# Altium schematic sheet CalNv1.1.SchDoc
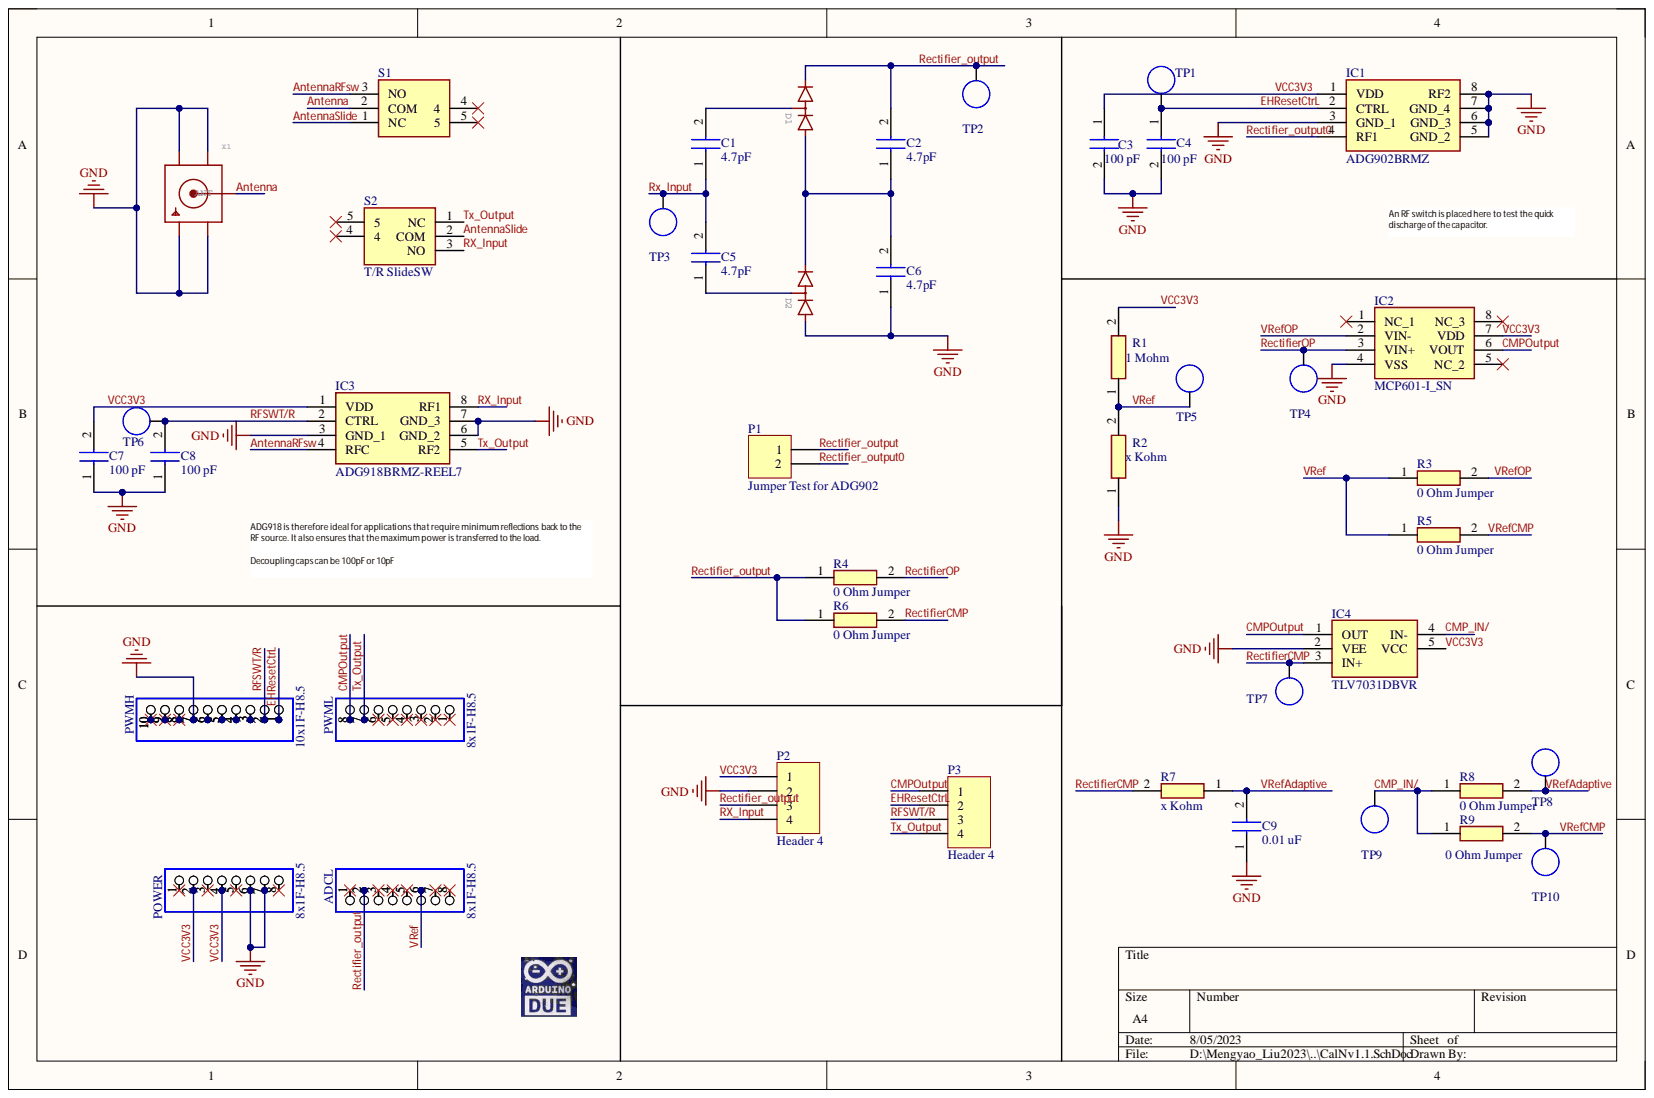
[diagram p0: CalNv1.1.SchDoc]

## Parts
ADCL  8x1F-H8.5  [1X08]
C1  4.7pF  [CAPC2012X95N]
C2  4.7pF  [CAPC2012X95N]
C3  100 pF  [CAPC2012X145N]
C4  100 pF  [CAPC2012X145N]
C5  4.7pF  [CAPC2012X95N]
C6  4.7pF  [CAPC2012X95N]
C7  100 pF  [CAPC2012X145N]
C8  100 pF  [CAPC2012X145N]
C9  0.01 uF  [CAPC2012X145N]
D1  RB706F-40FHT106  [SOT23]  (value not drawn)
D2  RB706F-40FHT106  [SOT23]  (value not drawn)
IC1  ADG902BRMZ  [SOP65P490X110-8N]
IC2  MCP601-I_SN  [SOIC127P600X175-8N]
IC3  ADG918BRMZ-REEL7  [SOP65P490X110-8N]
IC4  TLV7031DBVR  [SOT95P280X145-5N]
P1  Jumper Test for ADG902  [HDR1X2]
P2  Header 4  [HDR1X4]
P3  Header 4  [HDR1X4]
POWER  8x1F-H8.5  [1X08]
PWMH  10x1F-H8.5  [1X10]
PWML  8x1F-H8.5  [1X08]
R1  1 Mohm  [RESC2012X50N]
R2  x Kohm  [RESC2012X50N]
R3  0 Ohm Jumper  [RCC0805_e3]
R4  0 Ohm Jumper  [RCC0805_e3]
R5  0 Ohm Jumper  [RCC0805_e3]
R6  0 Ohm Jumper  [RCC0805_e3]
R7  x Kohm  [RESC2012X50N]
R8  0 Ohm Jumper  [RCC0805_e3]
R9  0 Ohm Jumper  [RCC0805_e3]
S1  Slide  [500ASSP1M6QE]
S2  T/R SlideSW  [500ASSP1M6QE]
TP1  TP  [TestPoint]  (no BOM)
TP2  TP  [TestPoint]  (no BOM)
TP3  TP  [TestPoint]  (no BOM)
TP4  TP  [TestPoint]  (no BOM)
TP5  TP  [TestPoint]  (no BOM)
TP6  TP  [TestPoint]  (no BOM)
TP7  TP  [TestPoint]  (no BOM)
TP8  TP  [TestPoint]  (no BOM)
TP9  TP  [TestPoint]  (no BOM)
TP10  TP  [TestPoint]  (no BOM)
X1  SMACONNECTOR_EDGE  [SMA_EDGELAUNCH]  (value not drawn)

## Nets
GND: C3.2 C4.2 C6.1 C7.1 C8.1 C9.1 D2.1 IC1.3(GND_1) IC1.5(GND_2) IC1.6(GND_3) IC1.7(GND_4) IC1.8(RF2) IC2.4(VSS) IC3.3(GND_1) IC3.6(GND_2) IC3.7(GND_3) IC4.2(VEE) P2.2 POWER.6 POWER.7 PWMH.7 R2.1 X1.GND@1 X1.GND@2 X1.GND@3 X1.GND@4
Antenna: S1.2(COM) X1.P(ANT)
AntennaRFsw: IC3.4(RFC) S1.3(NO)
AntennaSlide: S1.1(NC) S2.2(COM)
CMP_IN/: IC4.4(IN-) R8.1 R9.1 TP9.TP
CMPOutput: IC2.6(VOUT) IC4.1(OUT) P3.1 PWML.8
EHResetCtrL: C4.1 IC1.2(CTRL) P3.2 PWMH.1 TP1.TP
Rectifier_output: ADCL.2 C2.2 D1.2 P1.1 P2.3 R4.1 R6.1 TP2.TP
Rectifier_output0: IC1.4(RF1) P1.2
RectifierCMP: IC4.3(IN+) R6.2 R7.2 TP7.TP
RectifierOP: IC2.3(VIN+) R4.2 TP4.TP
RFSWT/R: C8.2 IC3.2(CTRL) P3.3 PWMH.2 TP6.TP
Rx_Input: C1.1 C5.2 IC3.8(RF1) P2.4 S2.3(NO) TP3.TP
Tx_Output: IC3.5(RF2) P3.4 PWML.7 S2.1(NC)
VCC3V3: C3.1 C7.2 IC1.1(VDD) IC2.7(VDD) IC3.1(VDD) IC4.5(VCC) P2.1 POWER.2 POWER.4 R1.2
VRef: ADCL.6 R1.1 R2.2 R3.1 R5.1 TP5.TP
VRefAdaptive: C9.2 R7.1 R8.2 TP8.TP
VRefCMP: R5.2 R9.2 TP10.TP
VRefOP: IC2.2(VIN-) R3.2
NetC1_2: C1.2 D1.3
NetC2_1: C2.1 C6.2 D1.1 D2.2
NetC5_1: C5.1 D2.3

Not connected: ADCL 1 3 4 5 7 8; IC2 1(NC_1) 5(NC_2) 8(NC_3); POWER 1 3 5 8; PWMH 3 4 5 6 8 9 10; PWML 1 2 3 4 5 6; S1 4 5; S2 4 5

## Library data of the parts
ADCL POWER PWML = PINHD-1X8: Component Kind: Standard; Library Name: Arduino_Due.IntLib
C1 C2 C5 C6 = CBR08C479BAGAC: Capacitor RF 4.7pF 0805; Manufacturer_Name: KEMET; Arrow Part Number: CBR08C479BAGAC; Arrow Price/Stock: https://www.arrow.com/en/products/cbr08c479bagac/kemet-corporation?region=nac; Component Kind: Standard; Datasheet Link: http://www.kemet.com/Lists/ProductCatalog/Attachments/490/KEM_C1030_CBR_SMD.pdf; Height: 0.95mm; Manufacturer_Part_Number: CBR08C479BAGAC; Mouser Part Number: 80-CBR08C479BAG; Mouser Price/Stock: https://www.mouser.co.uk/ProductDetail/KEMET/CBR08C479BAGAC?qs=BjUX18ghZXgBQ8MlHa06dg%3D%3D
C3 C4 C7 C8 = VJ0805A101JXBMC: Capacitor; Manufacturer_Name: Vishay; Arrow Part Number: VJ0805A101JXBMC; Arrow Price/Stock: https://www.arrow.com/en/products/vj0805a101jxbmc/vishay?region=nac; Component Kind: Standard; Datasheet Link: http://www.vishay.com/docs/45226/vj31xrohsautomlcc.pdf; Height: 1.45mm; Manufacturer_Part_Number: VJ0805A101JXBMC; Mouser Part Number: 77-VJ0805A101JXBMC; Mouser Price/Stock: https://www.mouser.co.uk/ProductDetail/Vishay-Vitramon/VJ0805A101JXBMC?qs=DyYCzLTSlfCgHy2DX6Cz6w%3D%3D
C9 = VJ0805Y103KXBAC: Capacitor; Manufacturer_Name: Vishay; Arrow Part Number: VJ0805Y103KXBAC; Arrow Price/Stock: https://www.arrow.com/en/products/vj0805y103kxbac/vishay?region=nac; Component Kind: Standard; Datasheet Link: http://www.vishay.com/docs/45226/vj31xrohsautomlcc.pdf; Height: 1.45mm; Manufacturer_Part_Number: VJ0805Y103KXBAC; Mouser Part Number: 77-VJ08Y100V103K; Mouser Price/Stock: https://www.mouser.co.uk/ProductDetail/Vishay-Vitramon/VJ0805Y103KXBAC?qs=IRuQNBqMOckF0HeddYSaNg%3D%3D
D1 D2 = DIODE_2CACA-SOT23: 2 Diodes - Cathode-Anode|Cathode-Anode DIODE_2CACA-SOT23; GateName_1: -B1; POPULARITY: 1; SymbolName_1: KA2KA; Component Kind: Standard; DeviceName: SOT23; DeviceSetName: DIODE_2CACA-; Library Name: RF_EHv1.1.IntLib; LibraryName: diode
IC1 = ADG902BRMZ: Integrated Circuit; Manufacturer_Name: Analog Devices; Arrow Part Number: ADG902BRMZ; Arrow Price/Stock: https://www.arrow.com/en/products/adg902brmz/analog-devices?region=nac; Component Kind: Standard; Datasheet Link: https://datasheet.datasheetarchive.com/originals/distributors/Datasheets-SFU1/DSASFU10004819.pdf; Height: 1.1mm; Manufacturer_Part_Number: ADG902BRMZ; Mouser Part Number: 584-ADG902BRMZ; Mouser Price/Stock: https://www.mouser.co.uk/ProductDetail/Analog-Devices/ADG902BRMZ?qs=BpaRKvA4VqGOESsiE6jGWw%3D%3D
IC2 = MCP601-I_SN: Integrated Circuit; Manufacturer_Name: Microchip; Manufacturer_Part_Number: MCP601-I/SN; Arrow Part Number: MCP601-I/SN; Arrow Price/Stock: https://www.arrow.com/en/products/mcp601-isn/microchip-technology?region=europe; Component Kind: Standard; Datasheet Link: http://ww1.microchip.com/downloads/en/DeviceDoc/21314g.pdf; Height: 1.75mm; Mouser Part Number: 579-MCP601-I/SN; Mouser Price/Stock: https://www.mouser.co.uk/ProductDetail/Microchip-Technology/MCP601-I-SN?qs=XsNSACV0tdVBbsVg6%2FBzzA%3D%3D
IC3 = ADG918BRMZ-REEL7: Integrated Circuit; Manufacturer_Name: Analog Devices; Arrow Part Number: ADG918BRMZ-REEL7; Arrow Price/Stock: https://www.arrow.com/en/products/adg918brmz-reel7/analog-devices; Component Kind: Standard; Datasheet Link: https://www.analog.com/media/en/technical-documentation/data-sheets/ADG918_919.pdf; Height: 1.1mm; Manufacturer_Part_Number: ADG918BRMZ-REEL7; Mouser Part Number: 584-ADG918BRMZ-R7; Mouser Price/Stock: https://www.mouser.co.uk/ProductDetail/Analog-Devices/ADG918BRMZ-REEL7?qs=BpaRKvA4VqEay0U7Dc17vw%3D%3D
IC4 = TLV7031DBVR: Integrated Circuit; Manufacturer_Name: Texas Instruments; Arrow Part Number: TLV7031DBVR; Arrow Price/Stock: https://www.arrow.com/en/products/tlv7031dbvr/texas-instruments?region=nac; Component Kind: Standard; Datasheet Link: http://www.ti.com/lit/gpn/TLV7031; Height: 1.45mm; Manufacturer_Part_Number: TLV7031DBVR; Mouser Part Number: 595-TLV7031DBVR; Mouser Price/Stock: https://www.mouser.co.uk/ProductDetail/Texas-Instruments/TLV7031DBVR?qs=EBDBlbfErPzxbC0zg598sw%3D%3D
P1 = Header 2: Header, 2-Pin; Component Kind: Standard; LatestRevisionDate: 17-Jul-2002; LatestRevisionNote: Re-released for DXP Platform.; Library Name: 常用元件集成库.IntLib; Publisher: Altium Limited
P2 P3 = Header 4: Header, 4-Pin; Component Kind: Standard; LatestRevisionDate: 17-Jul-2002; LatestRevisionNote: Re-released for DXP Platform.; Library Name: 常用元件集成库.IntLib; Publisher: Altium Limited
PWMH = PINHD-1X10: Component Kind: Standard; Library Name: DUE_V02g.IntLib
R1 R2 R7 = RCS0805100KFKEA: Resistor; Manufacturer_Name: Vishay; Arrow Part Number: RCS0805100KFKEA; Arrow Price/Stock: https://www.arrow.com/en/products/rcs0805100kfkea/vishay?region=nac; Component Kind: Standard; Datasheet Link: https://componentsearchengine.com/Datasheets/2/RCS0805100KFKEA.pdf; Height: 0.5mm; Library Name: SamacSys.SchLib; Manufacturer_Part_Number: RCS0805100KFKEA; Mouser Part Number: 71-RCS0805100KFKEA; Mouser Price/Stock: https://www.mouser.co.uk/ProductDetail/Vishay-Dale/RCS0805100KFKEA?qs=NKmfXavxMay%252BH0mbX9hKBw%3D%3D
R3-R6 R8 R9 = RCC08050000Z0EA: Resistor; Manufacturer_Name: Vishay; Arrow Part Number: RCC08050000Z0EA; Arrow Price/Stock: https://www.arrow.com/en/products/rcc08050000z0ea/vishay?region=nac; Component Kind: Standard; Datasheet Link: https://www.vishay.com/docs/20066/rcce3.pdf; Height: 0.5mm; Manufacturer_Part_Number: RCC08050000Z0EA; Mouser Part Number: 71-RCC08050000Z0EA; Mouser Price/Stock: https://www.mouser.co.uk/ProductDetail/Vishay-Dale/RCC08050000Z0EA?qs=GedFDFLaBXG1XappxO%252Bnuw%3D%3D
S1 S2 = 500ASSP1M6QE: Switch; Manufacturer_Name: E-Switch; Component Kind: Standard; Datasheet Link: https://www.mouser.com/datasheet/2/140/500A-346193.pdf; Height: 5.08mm; Library Name: SamacSys.SchLib; Manufacturer_Part_Number: 500ASSP1M6QE; Mouser Part Number: 612-500ASSP1M6QE; Mouser Price/Stock: https://www.mouser.co.uk/ProductDetail/E-Switch/500ASSP1M6QE?qs=%252BZnE%2FxbLNR9QgzPkMZRAQg%3D%3D
TP1-TP10 = TestPoint: Library Name: revibe_z.SchLib
X1 = SMACONNECTOR_EDGE: SMA Connector   90 DEGREE DIP SMA Connector, 50 Ohm (4UConnector: 07259); DeviceName: _EDGE; GateName_1: G$1; Component Kind: Standard; DeviceSetName: SMACONNECTOR; Library Name: RF_EHv1.1.IntLib; LibraryName: adafruit; SymbolName_1: SMACONNECTOR
